# Altium schematic sheet VGA_6bits.SchDoc
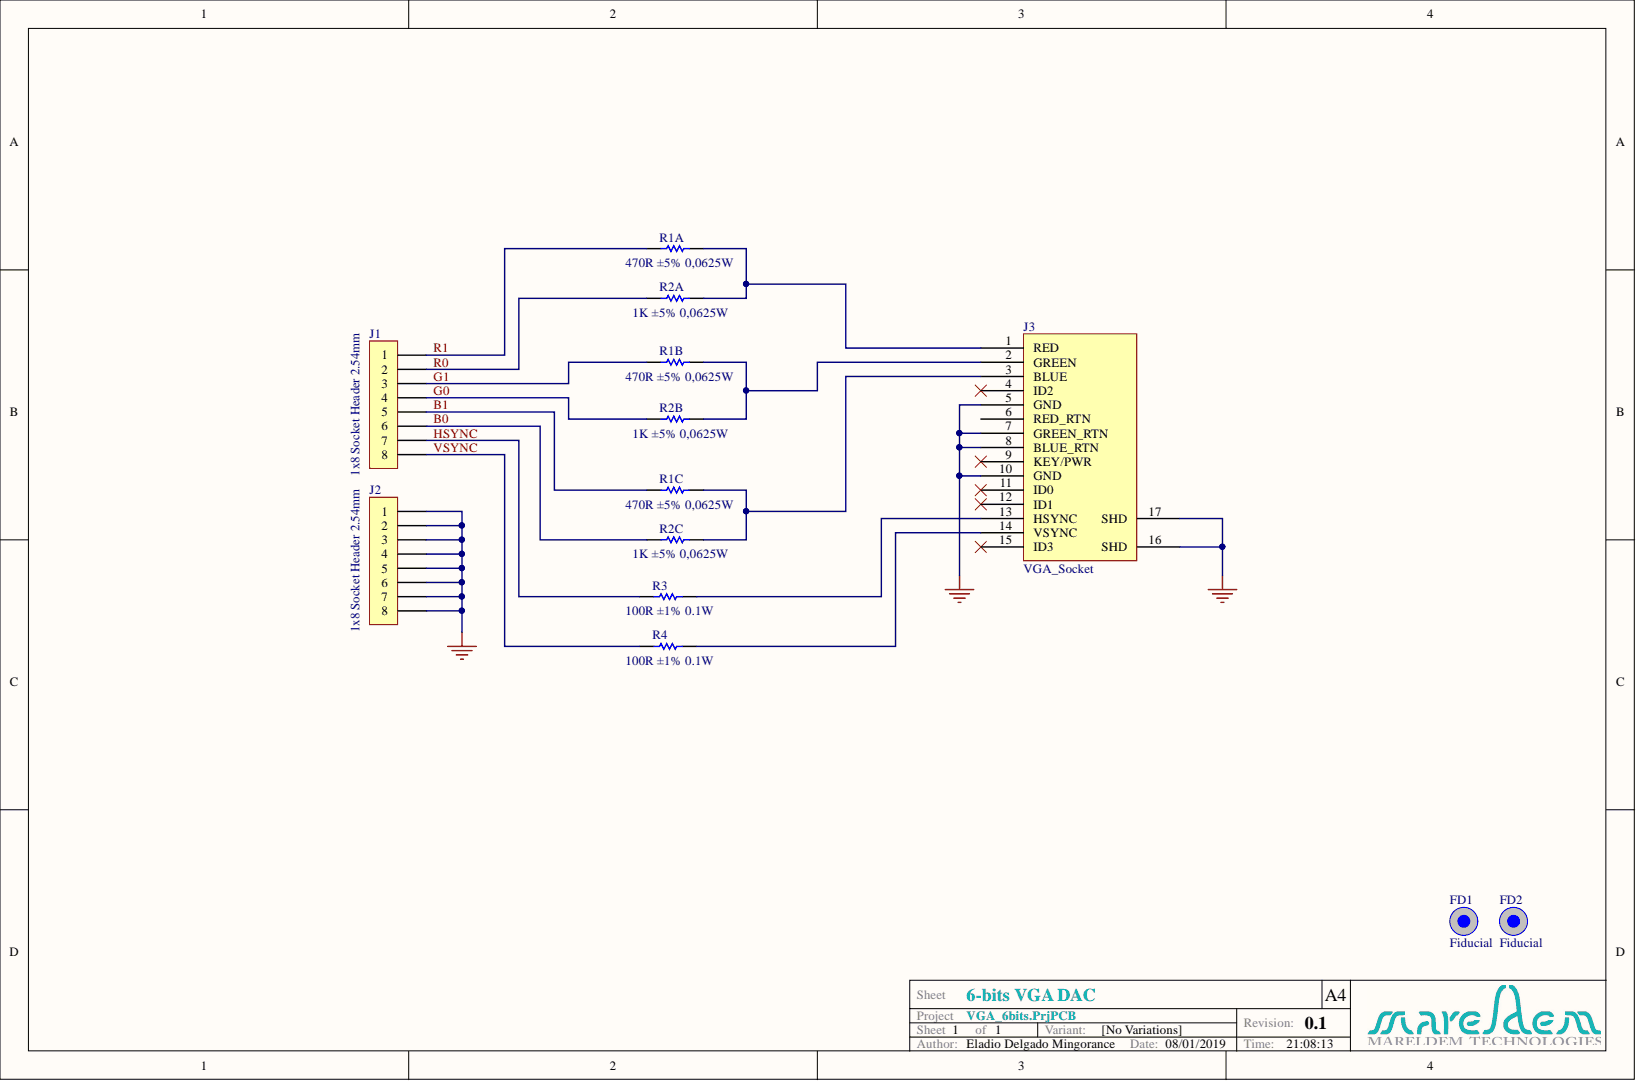
[diagram p0: VGA_6bits.SchDoc]

## Parts
FD1  Fiducial  [Fiducial_1mm]  (no BOM)
FD2  Fiducial  [Fiducial_1mm]  (no BOM)
J1  1x8 Socket Header 2.54mm  value 8  Pitch: 2.54mm  [1x8 Socket Header THT 2.54mm]
J2  1x8 Socket Header 2.54mm  value 8  Pitch: 2.54mm  [1x8 Socket Header THT 2.54mm]
J3  VGA_Socket  [VGA_RA_SLIM]
R1  470R ±5% 0,0625W  Power: 0,0625W  Resistance: 470R  Tolerance: ±5%  [4xArray_1206_Convex]  (parts A,B,C)
R2  1K ±5% 0,0625W  Power: 0,0625W  Resistance: 1K  Tolerance: ±5%  [4xArray_1206_Convex]  (parts A,B,C)
R3  value 100R ±1% 0.1W  Power: 0.1W  Resistance: 100R  Tolerance: ±1%  [R0603]  (hidden comment: Resistor)
R4  value 100R ±1% 0.1W  Power: 0.1W  Resistance: 100R  Tolerance: ±1%  [R0603]  (hidden comment: Resistor)

## Nets
GND: J2.1 J2.2 J2.3 J2.4 J2.5 J2.6 J2.7 J2.8 J3.5(GND) J3.7(GREEN_RTN) J3.8(BLUE_RTN) J3.10(GND) J3.16(SHD) J3.17(SHD)
B0: J1.6 R2.3
B1: J1.5 R1.3
G0: J1.4 R2.2
G1: J1.3 R1.2
HSYNC: J1.7 R3.1
R0: J1.2 R2.1
R1: J1.1 R1.1
VSYNC: J1.8 R4.1
NetJ3_1: J3.1(RED) R1.8 R2.8
NetJ3_2: J3.2(GREEN) R1.7 R2.7
NetJ3_3: J3.3(BLUE) R1.6 R2.6
NetJ3_13: J3.13(HSYNC) R3.2
NetJ3_14: J3.14(VSYNC) R4.2

Not connected: J3 4(ID2) 6(RED_RTN) 9(KEY/PWR) 11(ID0) 12(ID1) 15(ID3)

## Library data of the parts
FD1 FD2 = Fiducial 1mm: Fiducial Mark; Database Table Name: Fiducial$; Part Number: Fiducial 1mm; Library Name: Miscelanea.DbLib
J1 J2 = CON_SH_THT2.54_8: Connector, 8 pin Socket Header 2.54mm; Manufacturer: Samtec; Database Table Name: Con_Socket_Header_THT_2#54$; OrderingCode: SSW-108-01-T-S; OrderingCode 2: 613 008 118 21; Temp_ Max: 125; Temp_ Min: -40; Component Kind: Standard; Library Name: Connector_SocketHeader_2.54.DbLib; Manufacturer 2: Würth Elektronik; Part Number: CON_SH_THT2.54_8; Price (€): 0,438; Supplier: Samtec
J3 = VGA_RA_SLIM: Database Table Name: Video$; OrderingCode: VGA Socket RA; Component Kind: Standard; Part Number: VGA_RA_SLIM; Library Name: Connectors_AV.DbLib
R1 = 4xArray_1206_Convex_470R_0,0625W_±5%: Resistor; Manufacturer: Yageo; Database Table Name: ARRAY_5%$; OrderingCode: YC164-JR-07470RL; Temp_ Max: 155; Temp_ Min: -25; Component Kind: Standard; Library Name: Pasives_Resistor_SMD.DbLib; PackageDescription: SMD; Part Number: 4xArray_1206_Convex_470R_0,0625W_±5%; Price (€): 0,006; Value: 470R ±5% 0,0625W
R2 = 4xArray_1206_Convex_1K_0,0625W_±5%: Resistor; Manufacturer: Yageo; Database Table Name: ARRAY_5%$; OrderingCode: YC164-JR-071KL; Temp_ Max: 155; Temp_ Min: -25; Component Kind: Standard; Library Name: Pasives_Resistor_SMD.DbLib; PackageDescription: SMD; Part Number: 4xArray_1206_Convex_1K_0,0625W_±5%; Price (€): 0,005; Value: 1K ±5% 0,0625W
R3 R4 = R0603_100R_0.1W_±1%: Resistor, SMD; Manufacturer: Vishay; Database Table Name: R0603_1%$; OrderingCode: CRCW0603100RFK; Temp_ Max: 155; Temp_ Min: -55; Component Kind: Standard; Library Name: Pasives_Resistor_SMD.DbLib; PackageDescription: SMD; Part Number: R0603_100R_0.1W_±1%; Price (€): 0,014
